# Altium schematic sheet Sheet4.SchDoc
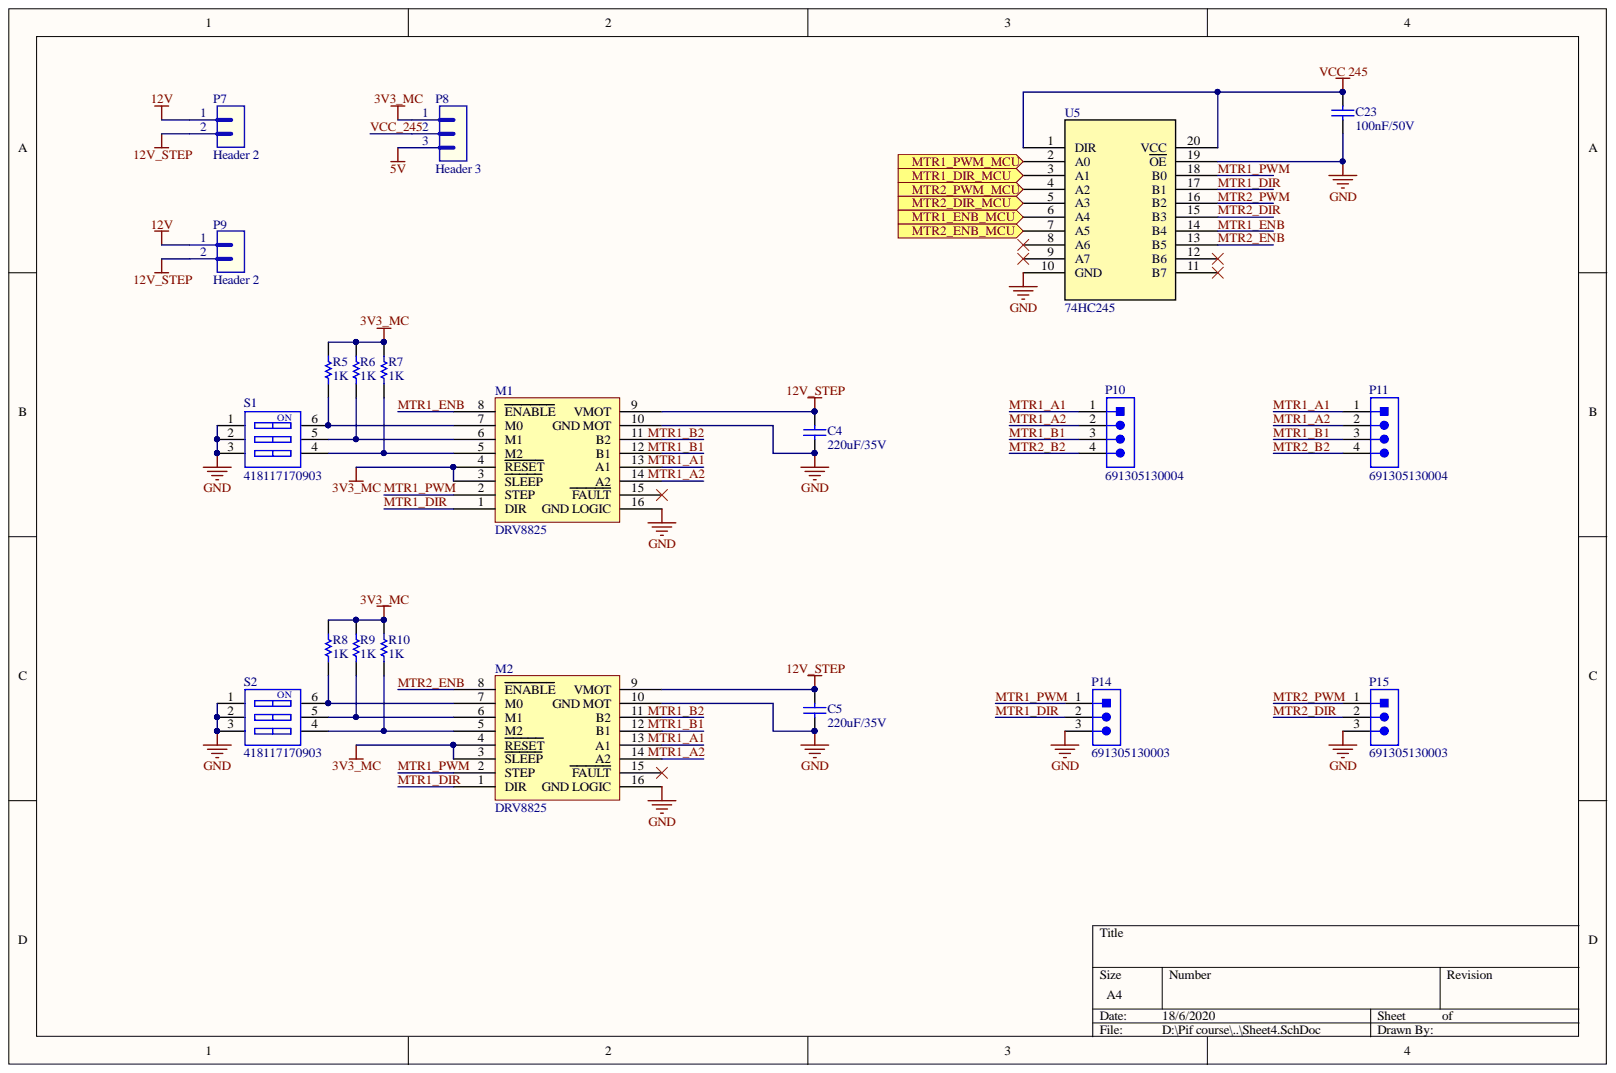
[diagram p0: Sheet4.SchDoc]

## Parts
C4  value 220uF/35V  [CAP-SM-0805]  (hidden comment: Cap-1nF-50V-0805)
C5  value 220uF/35V  [CAP-SM-0805]  (hidden comment: Cap-1nF-50V-0805)
C23  value 100nF/50V  [CAP-SM-0805]  (hidden comment: Cap-10uF-50V-0805)
M1  DRV8825  [DRV8825]
M2  DRV8825  [DRV8825]
P7  Header 2  [JP2]
P8  Header 3  [JP3]
P9  Header 2  [JP2]
P10  691305130004  [691305130004]
P11  691305130004  [691305130004]
P14  691305130003  [691305130003]
P15  691305130003  [691305130003]
R5  value 1K  [RES-SM-0805]  (hidden comment: Res-1K-0805)
R6  value 1K  [RES-SM-0805]  (hidden comment: Res-1K-0805)
R7  value 1K  [RES-SM-0805]  (hidden comment: Res-1K-0805)
R8  value 1K  [RES-SM-0805]  (hidden comment: Res-1K-0805)
R9  value 1K  [RES-SM-0805]  (hidden comment: Res-1K-0805)
R10  value 1K  [RES-SM-0805]  (hidden comment: Res-1K-0805)
S1  418117170903  [418117170903]
S2  418117170903  [418117170903]
U5  74HC245  [74HC245,625]

## Ports
MTR1_DIR_MCU, MTR1_ENB_MCU, MTR1_PWM_MCU, MTR2_DIR_MCU, MTR2_ENB_MCU, MTR2_PWM_MCU

## Nets
3V3_MC: M1.3(SLEEP) M1.4(RESET) M2.3(SLEEP) M2.4(RESET) P8.1 R5.2 R6.2 R7.2 R8.2 R9.2 R10.2
5V: P8.3
12V: P7.1 P9.1
12V_STEP: C4.2 C5.2 M1.9(VMOT) M2.9(VMOT) P7.2 P9.2
GND: C4.1 C5.1 C23.1 M1.10(GND_MOT) M1.16 M2.10(GND_MOT) M2.16 P14.3 P15.3 S1.1 S1.2 S1.3 S2.1 S2.2 S2.3 U5.10(GND) U5.19(OE)
VCC 245: C23.2 U5.1(DIR) U5.20(VCC)
MTR1_A1: P10.1 P11.1
MTR1_A2: P10.2 P11.2
MTR1_B1: P10.3 P11.3
MTR1_DIR: M1.1(DIR) M2.1(DIR) P14.2 U5.17(B1)
MTR1_DIR_MCU: U5.3(A1) ; port MTR1_DIR_MCU
MTR1_ENB: M1.8(ENABLE) U5.14(B4)
MTR1_ENB_MCU: U5.6(A4) ; port MTR1_ENB_MCU
MTR1_PWM: M1.2(STEP) M2.2(STEP) P14.1 U5.18(B0)
MTR1_PWM_MCU: U5.2(A0) ; port MTR1_PWM_MCU
MTR2_B2: P10.4 P11.4
MTR2_DIR: P15.2 U5.15(B3)
MTR2_DIR_MCU: U5.5(A3) ; port MTR2_DIR_MCU
MTR2_ENB: M2.8(ENABLE) U5.13(B5)
MTR2_ENB_MCU: U5.7(A5) ; port MTR2_ENB_MCU
MTR2_PWM: P15.1 U5.16(B2)
MTR2_PWM_MCU: U5.4(A2) ; port MTR2_PWM_MCU
VCC_245: P8.2
NetM1_5: M1.5(M2) R7.1 S1.4
NetM1_6: M1.6 R6.1 S1.5
NetM1_7: M1.7(M0) R5.1 S1.6
NetM2_5: M2.5 R10.1 S2.4
NetM2_6: M2.6(M1) R9.1 S2.5
NetM2_7: M2.7(M0) R8.1 S2.6
MTR1_B2:

Not connected: M1 11(B2) 12(B1) 13(A1) 14(A2) 15(FAULT); M2 11(B2) 12(B1) 13(A1) 14(A2) 15(FAULT); U5 8(A6) 9(A7) 11(B7) 12(B6)

## Library data of the parts
C4 C5 = Cap-1nF-50V-0805: CAP CER 1000PF 100V X7R 0805; Manufacturer: Johanson Dielectrics Inc.; Manufacturer Part Number: 101X15W102MV4E; Component Kind: Standard; Library Name: Capacitor.SchLib; Local Supplier: thegioiic; Local Supplier Link: https://thegioiic.com/products/tu-1nf-50v-0805; Supplier 1: Digikey; Supplier 1 Link: https://www.digikey.com/product-detail/en/johanson-dielectrics-inc/101X15W102MV4E/709-1335-1-ND/2327235; Supplier 1 Part Number: 709-1335-1-ND
C23 = Cap-10uF-50V-0805: CAP CER 10UF 50V X5R 0805; Manufacturer: Yageo; Manufacturer Part Number: CC0805KKX5R9BB106; Component Kind: Standard; Library Name: Capacitor.SchLib; Local Supplier: thegioiic; Local Supplier Link: https://thegioiic.com/products/tu-10uf-50v-0805; Supplier 1: Digikey; Supplier 1 Link: https://www.digikey.com/product-detail/en/yageo/CC0805KKX5R9BB106/311-3499-2-ND/7164383; Supplier 1 Part Number: 311-3499-2-ND
P7 P9 = Header 2: Header, 2-Pin; Component Kind: Standard; LatestRevisionDate: 17-Jul-2002; LatestRevisionNote: Re-released for DXP Platform.; Library Name: PIF_C16_lib.IntLib; Publisher: Altium Limited
P8 = Header 3: Header, 3-Pin; Component Kind: Standard; LatestRevisionDate: 17-Jul-2002; LatestRevisionNote: Re-released for DXP Platform.; Library Name: PIF_C16_lib.IntLib; Publisher: Altium Limited
P10 P11 = 691305130004: WR-TBL Serie 3051 Vertical PCB Header, Pluggable, pitch 3.5mm, 4p; Compliance: Lead free / RoHS; Modularity: No; Pin Number: 4; Rated Current [UL]: 12A; Working Voltage [UL]: 300VAC; Application: Pluggable; Component Kind: Standard; ComponentLink1Description: Manufacturer; ComponentLink1URL: http://www.we-online.de; ComponentLink2Description: Datasheet; ComponentLink2URL: https://katalog.we-online.de/em/datasheet/691305130004.pdf; Library Name: 691101710002_Altium_WR-TBL_rev19a.IntLib; Mounting Technology: THT; Operating Temperature: -40 to +105degC; Packaging: Box; Part Number: 691305130004; PCB/Cable/Panel: PCB; Pitch: 3.5mm; Published Date: October 2019; Type: Vertical; Publisher: Wurth Elektronik
P14 P15 = 691305130003: WR-TBL Serie 3051 Vertical PCB Header, Pluggable, pitch 3.5mm, 3p; Compliance: Lead free / RoHS; Modularity: No; Pin Number: 3; Rated Current [UL]: 12A; Working Voltage [UL]: 300VAC; Application: Pluggable; Component Kind: Standard; ComponentLink1Description: Manufacturer; ComponentLink1URL: http://www.we-online.de; ComponentLink2Description: Datasheet; ComponentLink2URL: https://katalog.we-online.de/em/datasheet/691305130003.pdf; Library Name: 691101710002_Altium_WR-TBL_rev19a.IntLib; Mounting Technology: THT; Operating Temperature: -40 to +105degC; Packaging: Box; Part Number: 691305130003; PCB/Cable/Panel: PCB; Pitch: 3.5mm; Published Date: October 2019; Type: Vertical; Publisher: Wurth Elektronik
R5-R10 = Res-1K-0805: RES 1K OHM 1% 1/4W 0805; Manufacturer: Stackpole Electronics Inc; Manufacturer Part Number: RNCP0805FTD1K00; Component Kind: Standard; Library Name: Resistor.SchLib; Local Supplier: thegioiic; Local Supplier Link: https://thegioiic.com/products/dien-tro-1k-ohm-0805-5; Supplier 1: Digikey; Supplier 1 Link: https://www.digikey.com/product-detail/en/stackpole-electronics-inc/RNCP0805FTD1K00/RNCP0805FTD1K00CT-ND/2240568; Supplier 1 Part Number: RNCP0805FTD1K00CT-ND
S1 S2 = 418117170903: WS-DITV Compact THT Dip Switch, Flat Actuator, pitch 2.54mm, 3p; Actuator Type: Flat Type; Circuit: SPST-NO; Operation Force: 800g; Pole Number: 3; Rated Current Non-Switching: 100mA; Rated Current Switching: 25mA; Rated Voltage Non-Switching: 50VDC; Rated Voltage Switching: 24VDC; Component Kind: Standard; ComponentLink1Description: Manufacturer; ComponentLink1URL: http://www.we-online.de; ComponentLink2Description: Datasheet; ComponentLink2URL: https://katalog.we-online.com/em/datasheet/418117170903.pdf; Contact Material: Copper Alloy; Contact Plating: Gold; Library Name: 418117170903_Altium_WS-DITV_rev19a.IntLib; Mounting Technology: THT; Operating Temperature: -40 to +85degC; Packaging: Tube; Part Number: 418117170903; Pitch: 2.54mm; Published Date: September 2019; Publisher: Wurth Elektronik
U5 = 74HC245: No Description Available; Built_By: EMA_UL_Team; SourceLibrary: TI_2002-01-01_7; Vendor: Texas Instruments; Component Kind: Standard; Library Name: Schlib1.SchLib; Manufacturer_Part_Number: 74HC245; RefDes: RefDes; Type: DEV; Copyright: Copyright (C) 2018 Accelerated Designs. All rights reserved
M1 M2 = DRV8825: Component Kind: Standard; Library Name: Schlib1.SchLib
